# Altium schematic sheet I2C_DRIVER.SchDoc
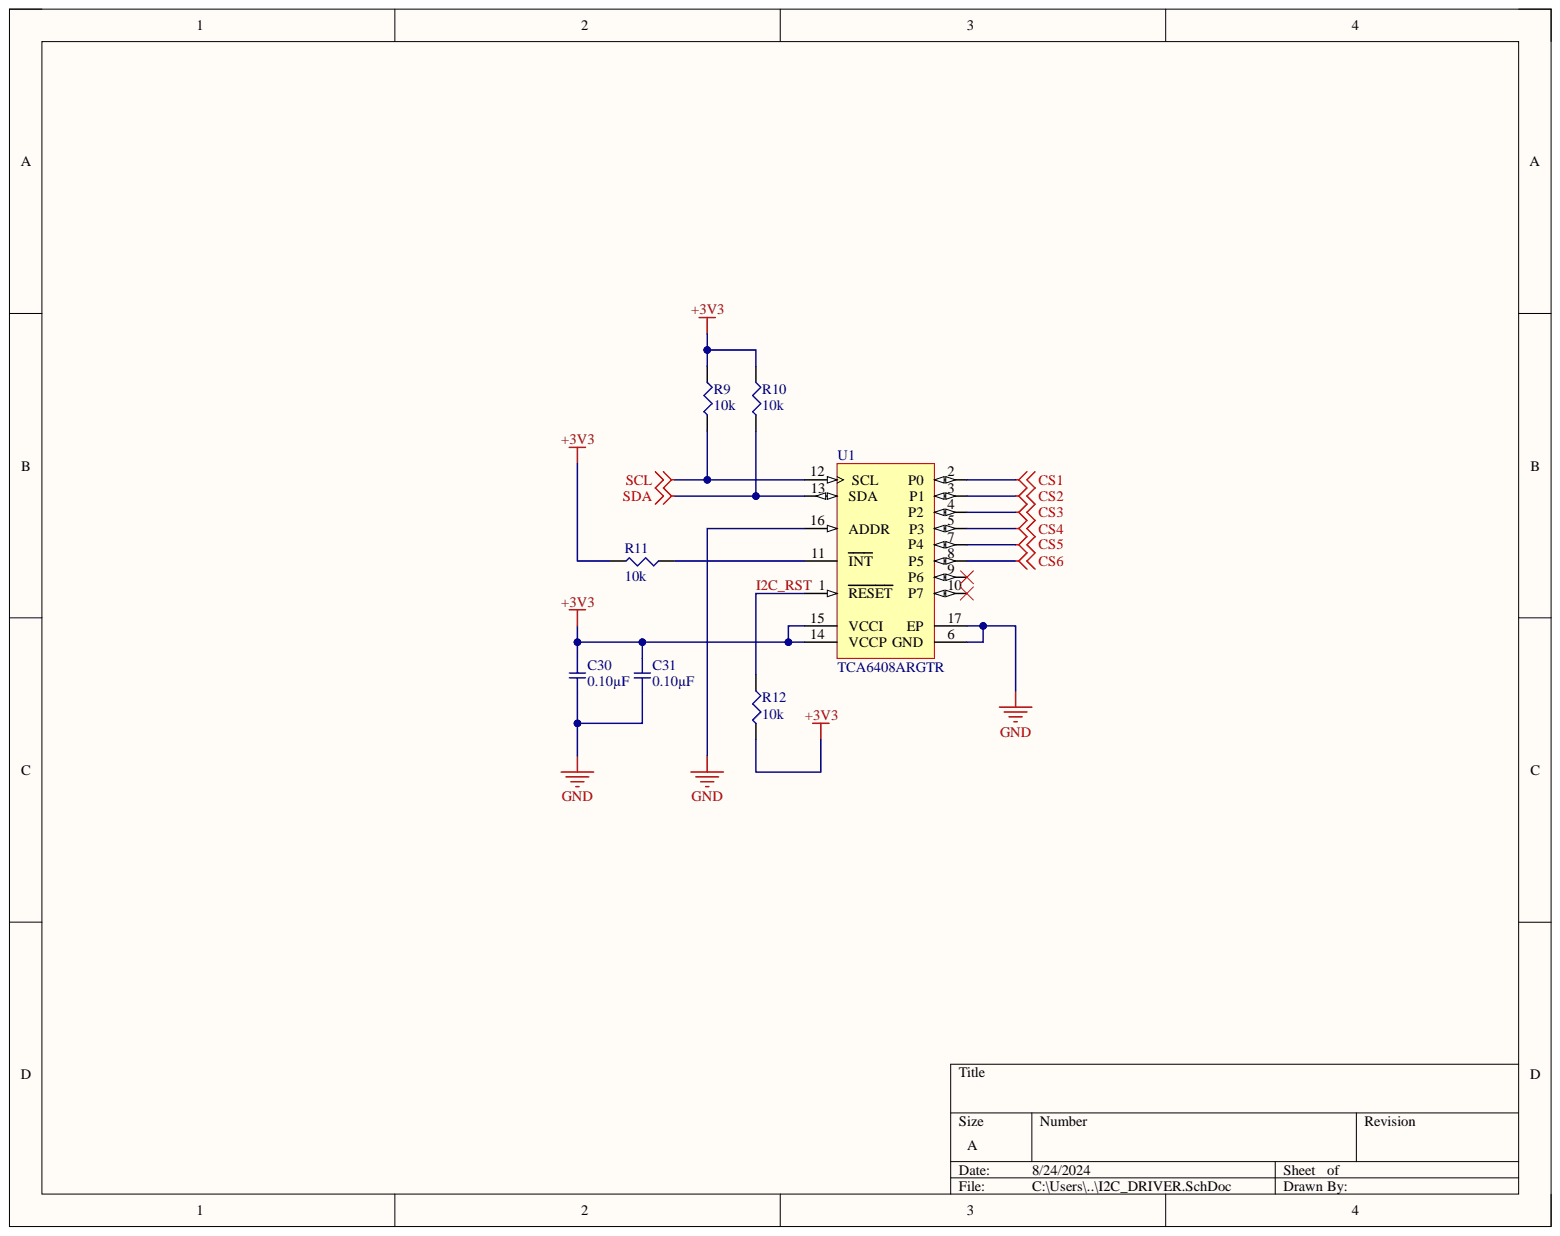
[diagram p0: I2C_DRIVER.SchDoc]

## Parts
C30  0.10µF  [SMD-0402C]
C31  0.10µF  [SMD-0402C]
R9  10k  [SMD-0402-RES]
R10  10k  [SMD-0402-RES]
R11  10k  [SMD-0402-RES]
R12  10k  [SMD-0402-RES]
U1  TCA6408ARGTR  [RGT0016A_V]

## Nets
+3V3: C30.1 C31.1 R9.1 R10.1 R11.2 R12.2 U1.14(VCCP) U1.15(VCCI)
CS1: U1.2(P0)
CS2: U1.3(P1)
CS3: U1.4(P2)
CS4: U1.5(P3)
CS5: U1.7(P4)
CS6: U1.8(P5)
GND: C30.2 C31.2 U1.6(GND) U1.16(ADDR) U1.17(EP)
SCL: R9.2 U1.12(SCL)
SDA: R10.2 U1.13(SDA)
I2C_RST: R12.1 U1.1(RESET)
NetR11_1: R11.1 U1.11(INT)

Not connected: U1 9(P6) 10(P7)

## Library data of the parts
C30 C31 = GCM155R71C104KA55D: CAP CER 0.1UF 16V X7R 0402; Manufacturer: Murata Electronics North America; @ qty: 0; Minimum Quantity: 1; Tolerance: ±10%; Unit Price (USD): 0.1; Applications: Automotive; Component Kind: Standard; Datasheets: http://www.murata.com/~/media/webrenewal/support/library/catalog/products/capacitor/mlcc/c03e.ashx; Digi-Key Part Number: 490-4759-1-ND; Factory Stock: 0; Features: -; Height - Seated (Max): -; Image: //media.digikey.com/Renders/Murata%20Renders/ZRB-SERIES-0402.jpg; Lead Spacing: -; Lead Style: -; Library Name: capacitor_murata_0402.IntLib; Mounting Type: Surface Mount, MLCC; Operating Temperature: -55°C ~ 125°C; Package / Case: 0402 (1005 Metric); Packaging: Cut Tape (CT); Part Number: GCM155R71C104KA55D; Part Status: Active; Quantity Available: 734194; Ratings: AEC-Q200; Series: GCM; Size / Dimension: 0.039" L x 0.020" W (1.00mm x 0.50mm); Temperature Coefficient: X7R; Thickness (Max): 0.022" (0.55mm); Value: 0.10µF; Voltage: 16V
R9-R12 = ERJ-2GEJ103X: RES SMD 10K OHM 5% 1/10W 0402; Manufacturer: Panasonic Electronic Components; @ qty: 1500; Factory Stock: 0; Minimum Quantity: 1; Power (Watts): 0.1W, 1/10W; Temperature Coefficient: ±200ppm/°C; Unit Price (USD): 0.00350; Component Kind: Standard; Composition: Thick Film; Datasheets: http://industrial.panasonic.com/www-cgi/jvcr13pz.cgi?E+PZ+3+AOA0001+ERJ2GEJ103X+7+WW; Digi-Key Part Number: P10KJCT-ND; Failure Rate: -; Features: Automotive AEC-Q200; Height - Seated (Max): 0.016" (0.40mm); Image: //media.digikey.com/Photos/Panasonic%20Photos/ERJ-PA2-Series.jpg; Library Name: Panasonic_ERJ_0402.IntLib; Number of Terminations: 2; Operating Temperature: -55°C ~ 155°C; Package / Case: 0402 (1005 Metric); Packaging: Cut Tape (CT); Part Number: ERJ-2GEJ103X; Part Status: Active; Quantity Available: 2560215; Series: ERJ; Size / Dimension: 0.039" L x 0.020" W (1.00mm x 0.50mm); Supplier Device Package: 0402; Tolerance: ±5%; Value: 10k
U1 = CMP-0314-00034-2: Low-Voltage 8-Bit I2C and SMBus I/O Expander, 1.65 to 5.5 V, -40 to 85 degC, 16-pin QFN (RGT), Green (RoHS & no Sb/Br); Manufacturer: Texas Instruments; 5V Tolerant I/O: Yes; # of I/Os: 8; fSCLK(Max)(MHz): 400; I2C Address: 0100 00x; SubFamily: I2C IO Expander; VCC(Max)(V): 5.5; VCC(Min)(V): 1.65; Code_JEDEC: MO-220; Component Kind: Standard; ComponentLink1Description: Datasheet; ComponentLink1URL: http://www.ti.com/general/docs/lit/getliterature.tsp?genericPartNumber=TCA6408A&fileType=pdf; ComponentLink2Description: Package Specification; ComponentLink2URL: http://www.ti.com/litv/pdf/mpds361; Configuration Registers: Yes; DatasheetVersion: SCPS192C; Eco-Plan: Green (RoHS & no Sb/Br); Interrupt Output: Yes; Library Name: Altium Content Vault; Low Power: Yes; Max Frequency(kHz): 400; Mounting Technology: Surface Mount; No. of Outputs: 8; PackageDescription: 16-Pin Plastic Quad Flat No-Lead, 3 x 3 mm Body, 0.5 mm Pitch, 1.45 x 1.45 mm Exposed Pad; PackageReference: RGT0016A; PackageVersion: revH, Oct-2011; PartNumber: TCA6408ARGTR; Push-Pull I/O Type: Yes; Reset Input: Yes; Vcc range(V): 1.65 to 5.5
